# Altium schematic sheet [08] - WIRELESS.SchDoc
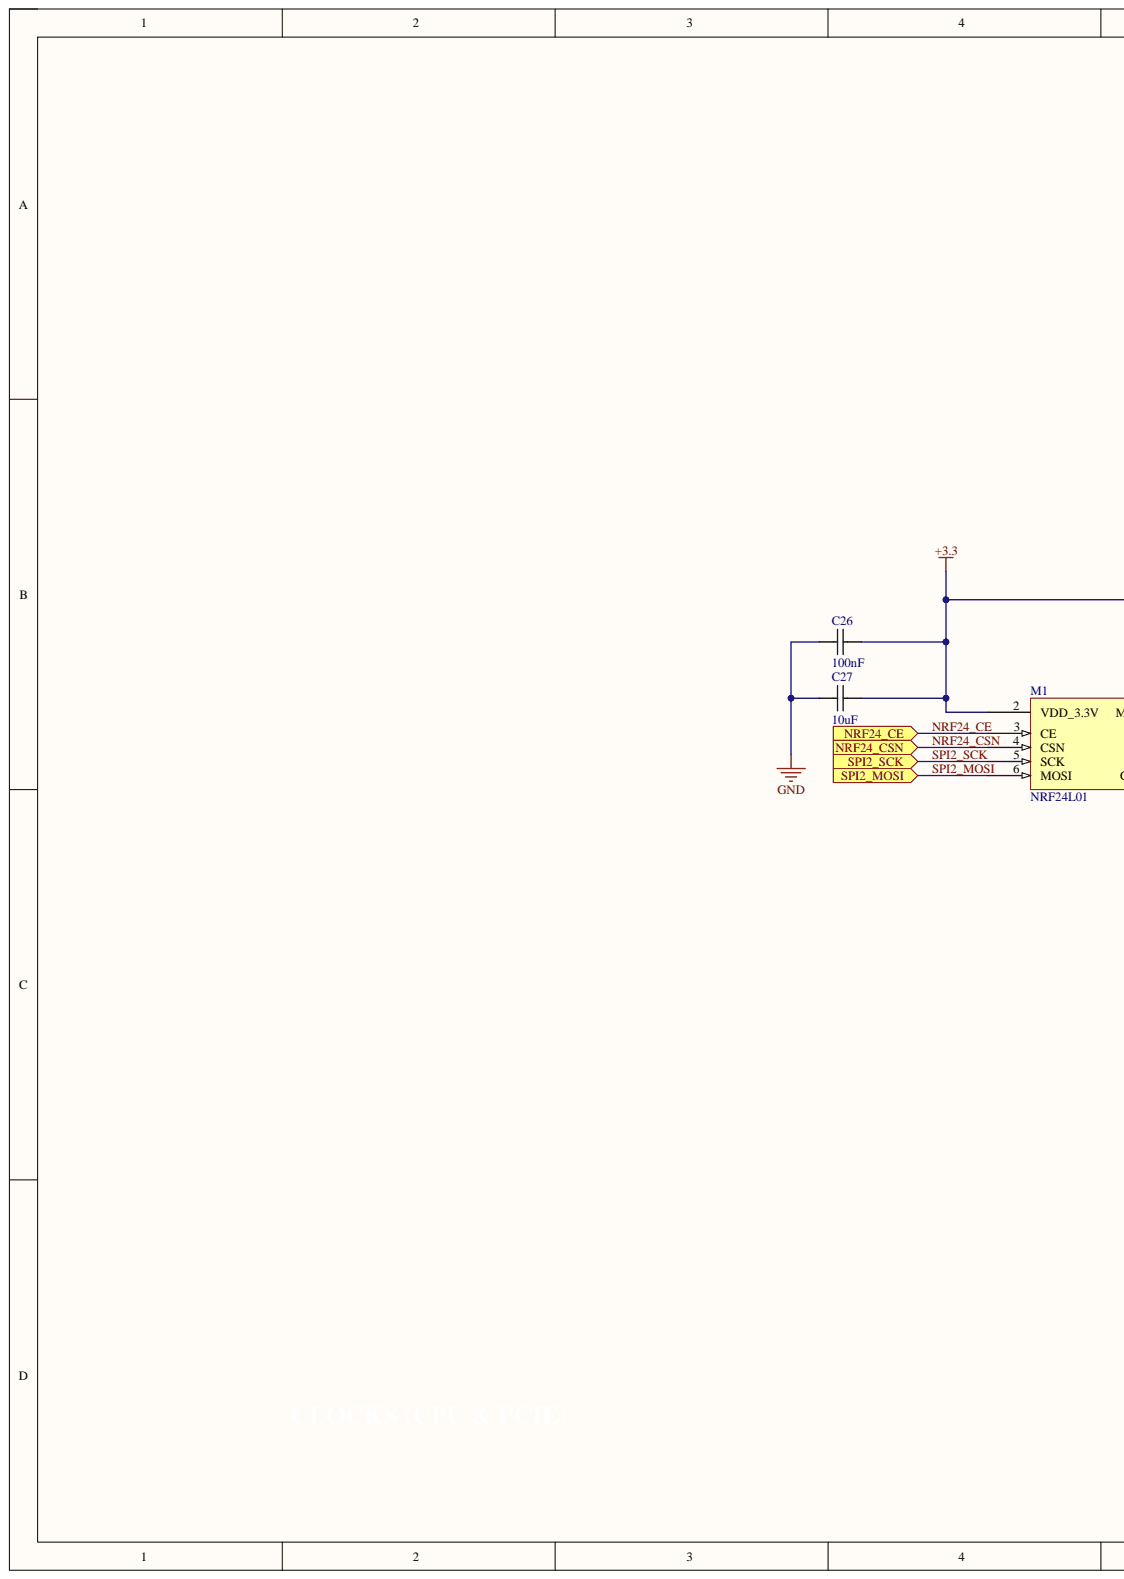
[diagram p0: [08] - WIRELESS.SchDoc, left]
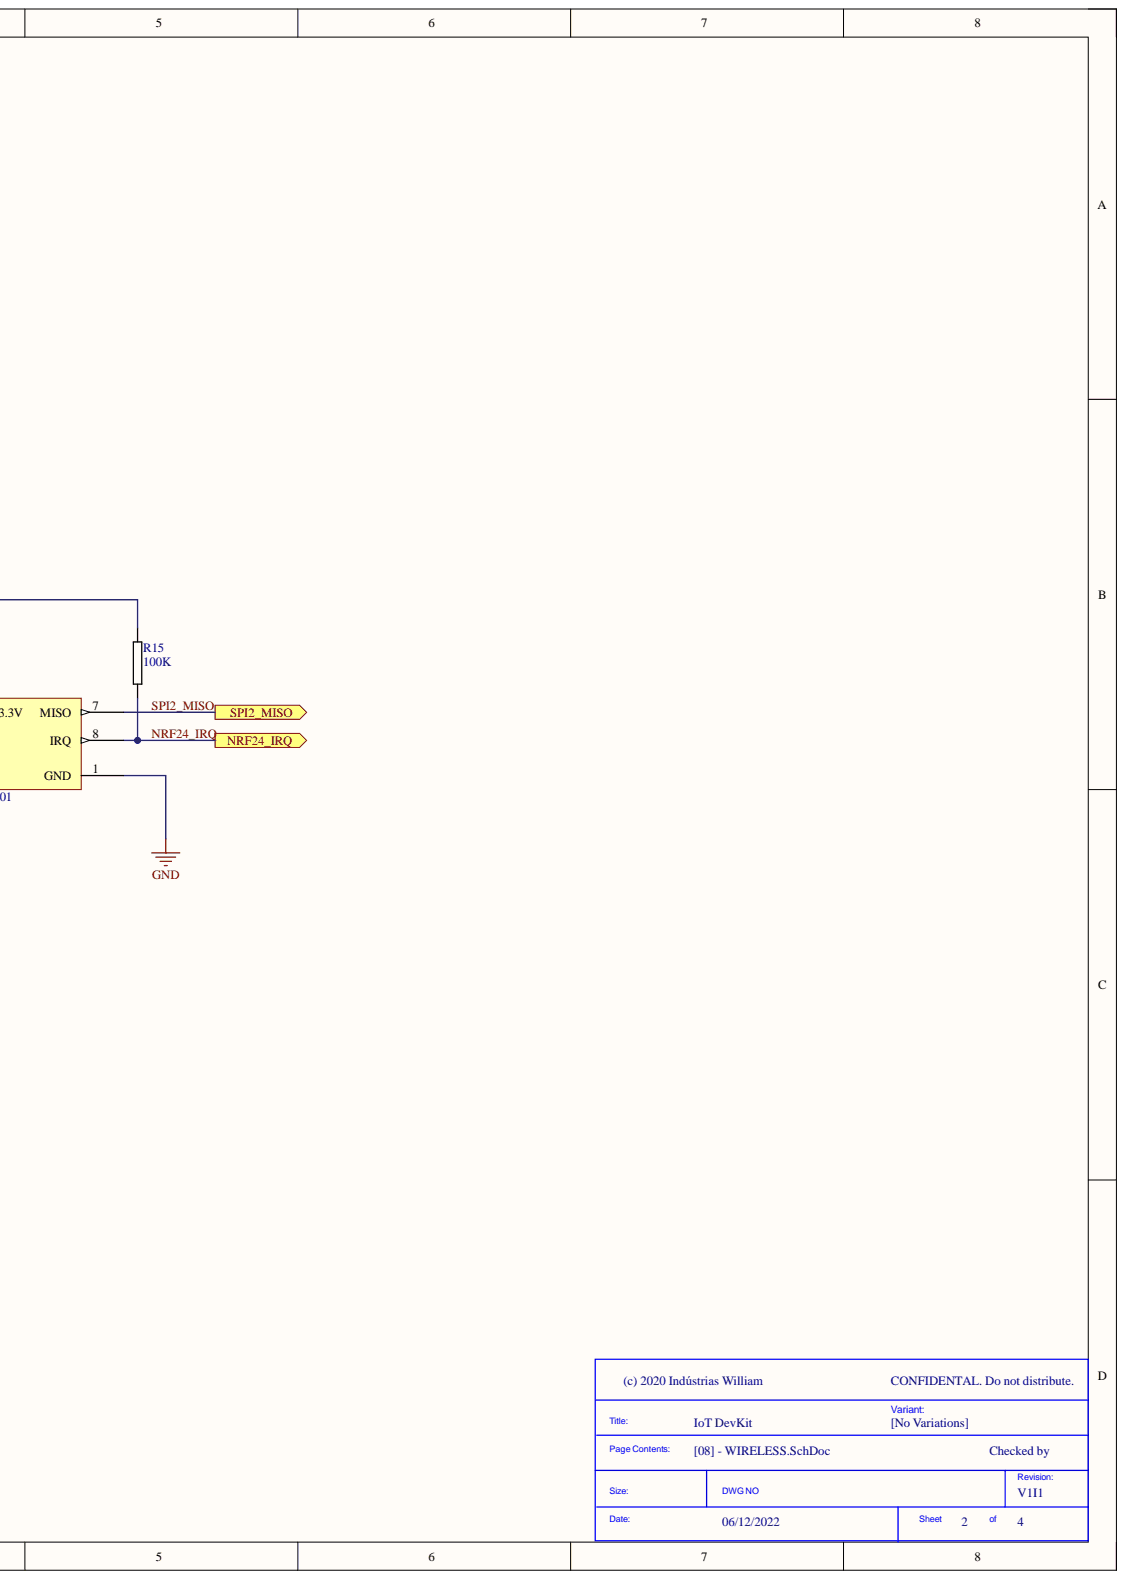
[diagram p1: [08] - WIRELESS.SchDoc, right]

## Parts
C26  100nF  [0603C-GENERIC]
C27  10uF  [0603C-GENERIC]
M1  NRF24L01  [NRF24L01-40.8x15.3mm]
R15  100K  [0603R-100K]

## Ports
NRF24_CE, NRF24_CSN, NRF24_IRQ, SPI2_MISO, SPI2_MOSI, SPI2_SCK

## Nets
+3.3: C26.2 C27.2 M1.2(VDD_3.3V) R15.1
GND: C26.1 C27.1 M1.1(GND)
NRF24_CE: M1.3(CE) ; port NRF24_CE
NRF24_CSN: M1.4(CSN) ; port NRF24_CSN
NRF24_IRQ: M1.8(IRQ) R15.2 ; port NRF24_IRQ
SPI2_MISO: M1.7(MISO) ; port SPI2_MISO
SPI2_MOSI: M1.6(MOSI) ; port SPI2_MOSI
SPI2_SCK: M1.5(SCK) ; port SPI2_SCK

## Library data of the parts
C26 = 0603C-100NF-25V-X7R-TDK: CAPACITOR 0603 CERAMIC; Manufacturer: TDK Corporation; Manufacturer Part Number: C1608X7R1E104K; Reel: C12; Component Kind: Standard; Library Name: vh_altium_library.intLib; Supplier 1: Digi-Key; Supplier Part Number 1: 445-1316-2-ND
C27 = 0603C-10UF-6V3-X5R-MUR: CAPACITOR 0603 CERAMIC; Manufacturer: Murata Electronics North America; Manufacturer Part Number: GRM188R60J106ME47D; Reel: C24; Component Kind: Standard; Library Name: vh_altium_library.intLib; Supplier 1: Digi-Key; Supplier Part Number 1: 490-3896-2-ND
M1 = NRF24L01-DIP: Wireless Module; Component Kind: Standard; Library Name: Componentes Deived Projetos.IntLib
R15 = 0603R-100K: GENERIC RESISTOR 0603; Manufacturer: Stackpole Electronics Inc; Manufacturer Part Number: RMCF 1/16 100K 1% R; Power (Watts): 0.1W, 1/10W; REEL: R47; Temperature Coefficient: ±100ppm/°C; Tolerance: ±1%; Case: 0603 (1608 metric); Category: Resistors; Component Kind: Standard; Composition: Thick Film; Lead Style: Surface Mount (SMD - SMT); Library Name: vh_altium_library.intLib; Packaging: Cut Tape (CT); Resistance In Ohms: 100K; RoHS: RoHS Compliant; Supplier: Digi-Key; Supplier 1: Digi-Key; Supplier Part Number: RMCF1/16100KFRCT-ND; Supplier Part Number 1: RMCF0603FT100KTR-ND
